# Altium schematic sheet Sheet3_V2.SchDoc(Sheet3_V2)
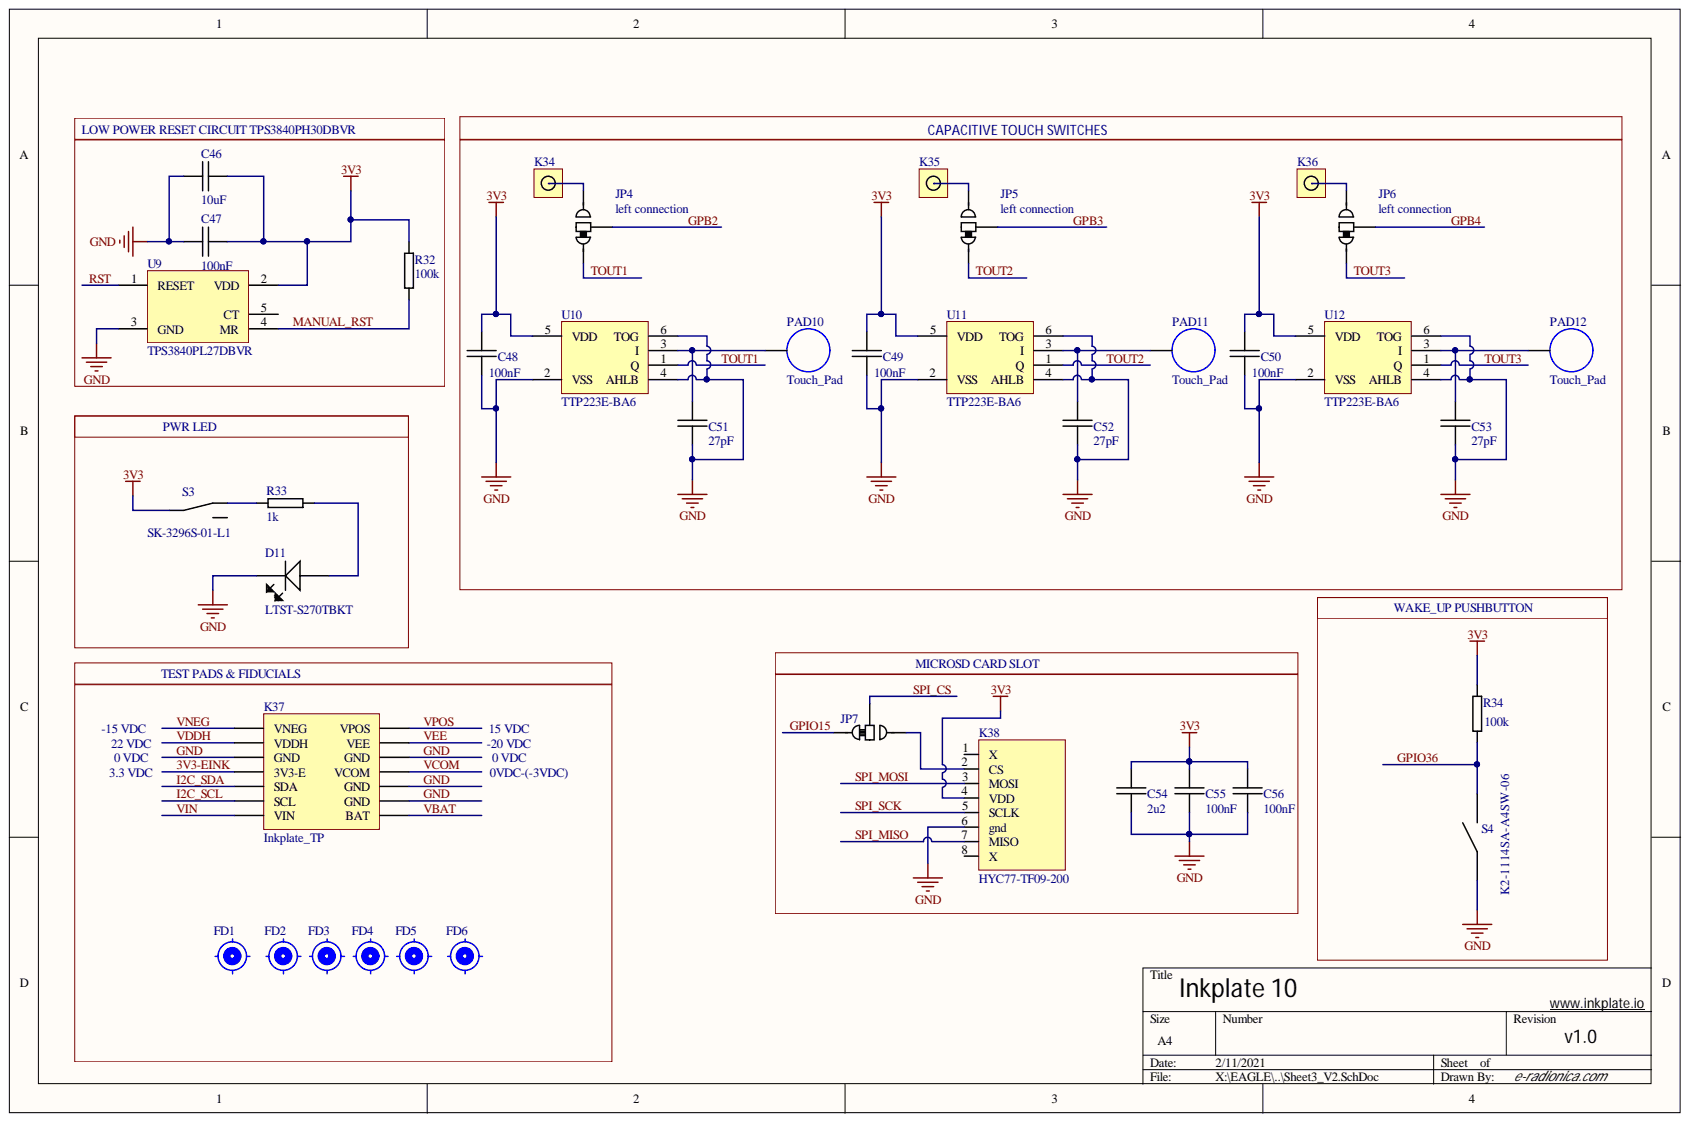
[diagram p0: Sheet3_V2.SchDoc]

## Parts
C46  10uF  [1206C]
C47  100nF  [0603C]
C48  100nF  [0603C]
C49  100nF  [0603C]
C50  100nF  [0603C]
C51  27pF  [0603C]
C52  27pF  [0603C]
C53  27pF  [0603C]
C54  2u2  [0603C]
C55  100nF  [0603C]
C56  100nF  [0603C]
D11  LTST-S270TBKT  [0603 Side view LED LTST-S270GKT]
FD1  Fiducial_Stencil  [Fiducial_1mm_Paste]  (value not drawn)  (no BOM)
FD2  Fiducial_Stencil  [Fiducial_1mm_Paste]  (value not drawn)  (no BOM)
FD3  Fiducial_Stencil  [Fiducial_1mm_Paste]  (value not drawn)  (no BOM)
FD4  Fiducial_Stencil  [Fiducial_1mm_Paste]  (value not drawn)  (no BOM)
FD5  Fiducial_Stencil  [Fiducial_1mm_Paste]  (value not drawn)  (no BOM)
FD6  Fiducial_Stencil  [Fiducial_1mm_Paste]  (value not drawn)  (no BOM)
JP4  left connection  [SMD_JUMPER 2/3 pads left connection]
JP5  left connection  [SMD_JUMPER 2/3 pads left connection]
JP6  left connection  [SMD_JUMPER 2/3 pads left connection]
JP7  2/3 pads connected  [SMD_JUMPER 2/3 pads]  (value not drawn)
K34  HEADER 1x1  [HEADER MALE 1x1]  (value not drawn)
K35  HEADER 1x1  [HEADER MALE 1x1]  (value not drawn)
K36  HEADER 1x1  [HEADER MALE 1x1]  (value not drawn)
K37  Inkplate_TP  [Inkplate test header]
K38  HYC77-TF09-200  [HYC77-TF09-200]
PAD10  Touch_Pad  [Touch_Pad]
PAD11  Touch_Pad  [Touch_Pad]
PAD12  Touch_Pad  [Touch_Pad]
R32  100k  [0603R]
R33  1k  [0603R]
R34  100k  [0603R]
S3  SK-3296S-01-L1  [SK-3296S-01-L1]
S4  K2-1114SA-A4SW-06  [K2-1114SA-A4SW-06]
U9  TPS3840PL27DBVR  [TPS3840PH30DBVR]
U10  TTP223E-BA6  [SOT-23-6]
U11  TTP223E-BA6  [SOT-23-6]
U12  TTP223E-BA6  [SOT-23-6]

## Nets
3V3: C46.2 C47.2 C48.2 C49.2 C50.2 C54.2 C55.2 C56.2 K38.4(VDD) R32.2 R34.2 S3.2 U9.2(VDD) U10.5(VDD) U11.5(VDD) U12.5(VDD)
3V3-EINK: K37.4(3V3-E)
GND: C46.1 C47.1 C48.1 C49.1 C50.1 C51.2 C52.2 C53.2 C54.1 C55.1 C56.1 D11.2 K37.3(GND) K37.9(GND) K37.10(GND) K37.12(GND) K38.6(gnd) S4.1 U9.3(GND) U10.2(VSS) U10.4(AHLB) U10.6(TOG) U11.2(VSS) U11.4(AHLB) U11.6(TOG) U12.2(VSS) U12.4(AHLB) U12.6(TOG)
GPB2: JP4.2
GPB3: JP5.2
GPB4: JP6.2
GPIO15: JP7.3
GPIO36: R34.1 S4.2
I2C_SCL: K37.6(SCL)
I2C_SDA: K37.5(SDA)
MANUAL_RST: R32.1 U9.4(MR)
RST: U9.1(RESET)
SPI_CS: JP7.2
SPI_MISO: K38.7(MISO)
SPI_MOSI: K38.3(MOSI)
SPI_SCK: K38.5(SCLK)
TOUT1: JP4.1 U10.1(Q)
TOUT2: JP5.1 U11.1(Q)
TOUT3: JP6.1 U12.1(Q)
VBAT: K37.8(BAT)
VCOM: K37.11(VCOM)
VDDH: K37.2(VDDH)
VEE: K37.13(VEE)
VIN: K37.7(VIN)
VNEG: K37.1(VNEG)
VPOS: K37.14(VPOS)
NetC51_1: C51.1 PAD10.1 U10.3(I)
NetC52_1: C52.1 PAD11.1 U11.3(I)
NetC53_1: C53.1 PAD12.1 U12.3(I)
NetD11_1: D11.1 R33.2
NetJP4_3: JP4.3 K34.1
NetJP5_3: JP5.3 K35.1
NetJP6_3: JP6.3 K36.1
NetJP7_1: JP7.1 K38.2(CS)
NetR33_1: R33.1 S3.3

Not connected: K38 1(X) 8(X); S3 1; U9 5(CT)

## Library data of the parts
C46 = 1206C: Component Kind: Standard; Library Name: e-radionica.com schematics.SchLib
C47-C56 = 0603C: Component Kind: Standard; Library Name: e-radionica.com schematics.SchLib
D11 = 0603LED_side view: Component Kind: Standard; Library Name: e-radionica.com schematics.SchLib
FD1-FD6 = Fiducial_Stencil: ROUND 1mm; Library Name: e-radionica.com schematics.SchLib
JP4-JP6 = SMD_JUMPER 2/3 Left pad connected: Component Kind: Standard; Library Name: e-radionica.com schematics.SchLib
JP7 = SMD_JUMPER 2/3 pads connected: Component Kind: Standard; Library Name: e-radionica.com schematics.SchLib
K37 = Inkplate test header: Component Kind: Standard; Library Name: e-radionica.com schematics.SchLib
K38 = HYC77-TF09-200: MicroSD Inkplate; Component Kind: Standard; Library Name: e-radionica.com schematics.SchLib
R32-R34 = 0603R: Component Kind: Standard; Library Name: e-radionica.com schematics.SchLib
S3 = Switch SK-3296S-01-L1: Component Kind: Standard; Library Name: e-radionica.com schematics.SchLib
S4 = Pushbutton K2-1114SA-A4SW-06: Inkplate; Component Kind: Standard; Library Name: e-radionica.com schematics.SchLib
U9 = TPS3840PH30DBVR: Component Kind: Standard; Library Name: e-radionica.com schematics.SchLib
U10-U12 = TTP223E: Component Kind: Standard; Library Name: e-radionica.com schematics.SchLib
K34-K36 = HEADER 1x1: Component Kind: Standard; Library Name: e-radionica.com schematics.SchLib
PAD10-PAD12 = Touch_Pad: Component Kind: Standard; Library Name: e-radionica.com schematics.SchLib
